# Altium schematic sheet Motor-Driver.SchDoc(Motor-Driver)
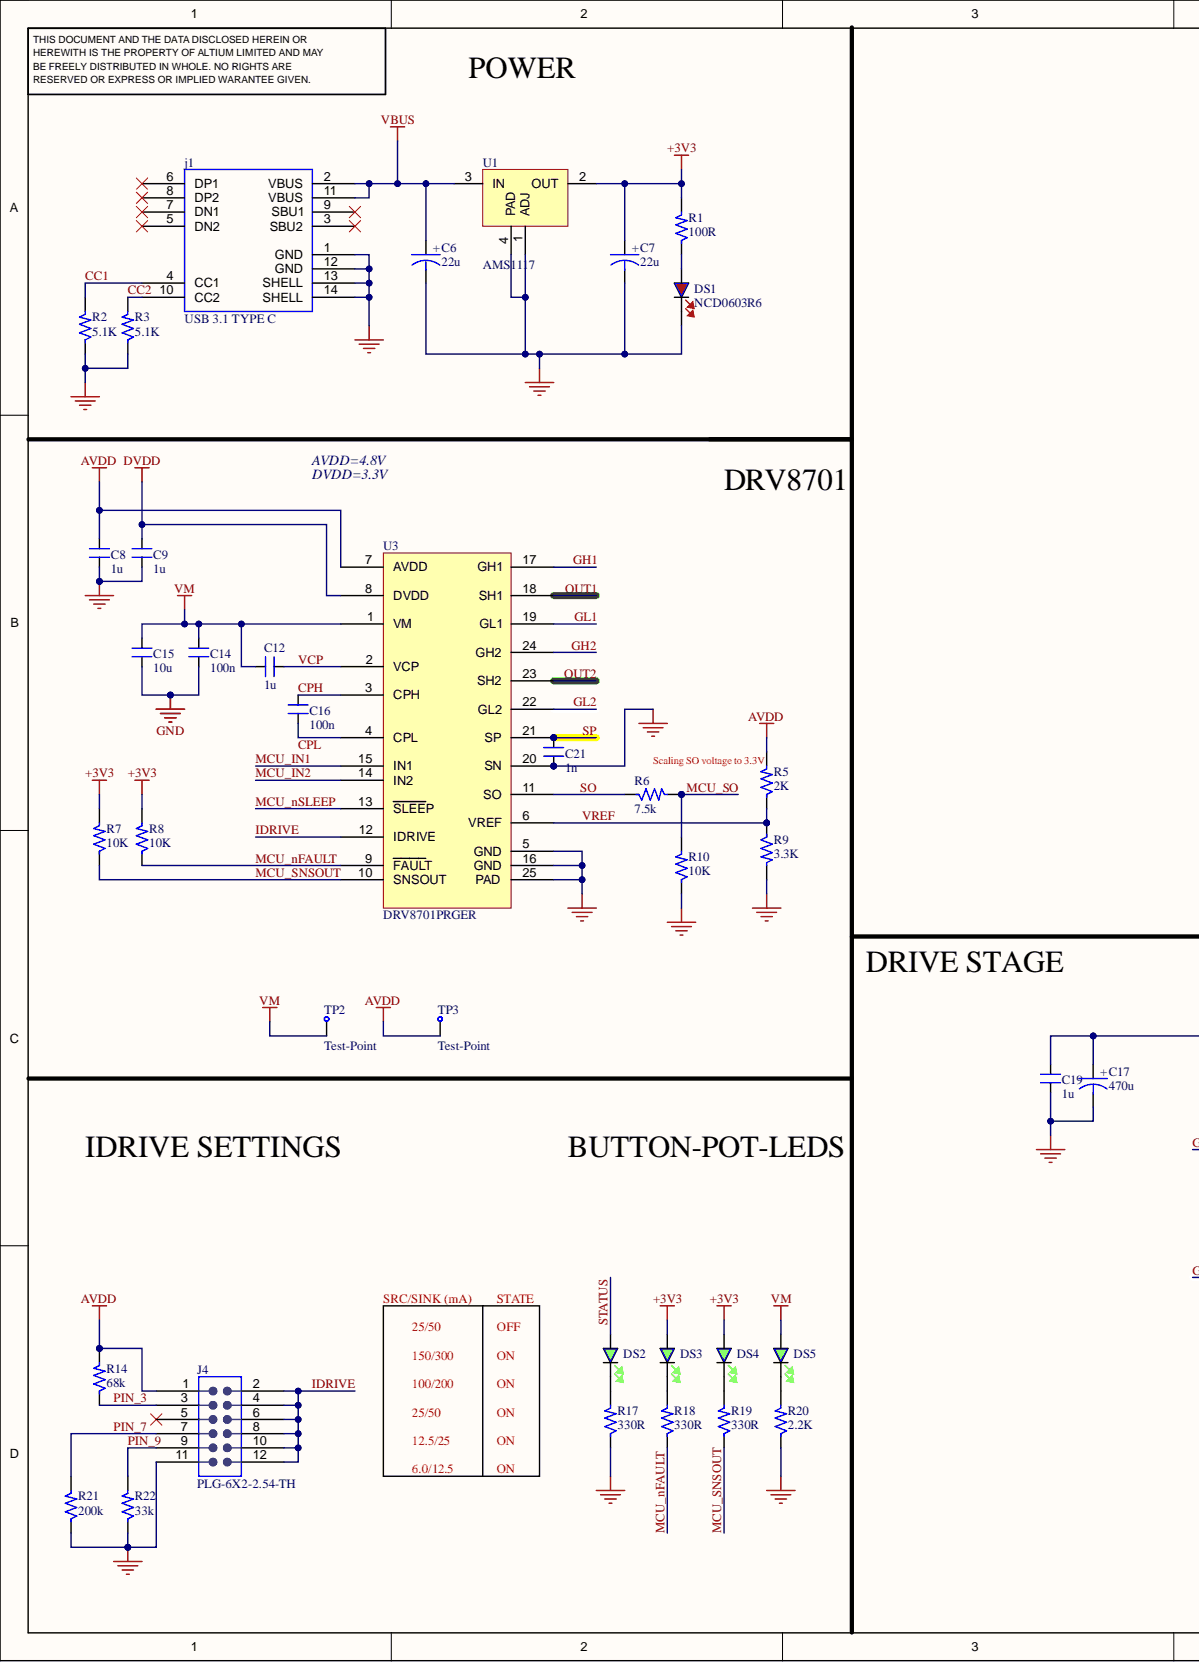
[diagram p0: Motor-Driver.SchDoc, left]
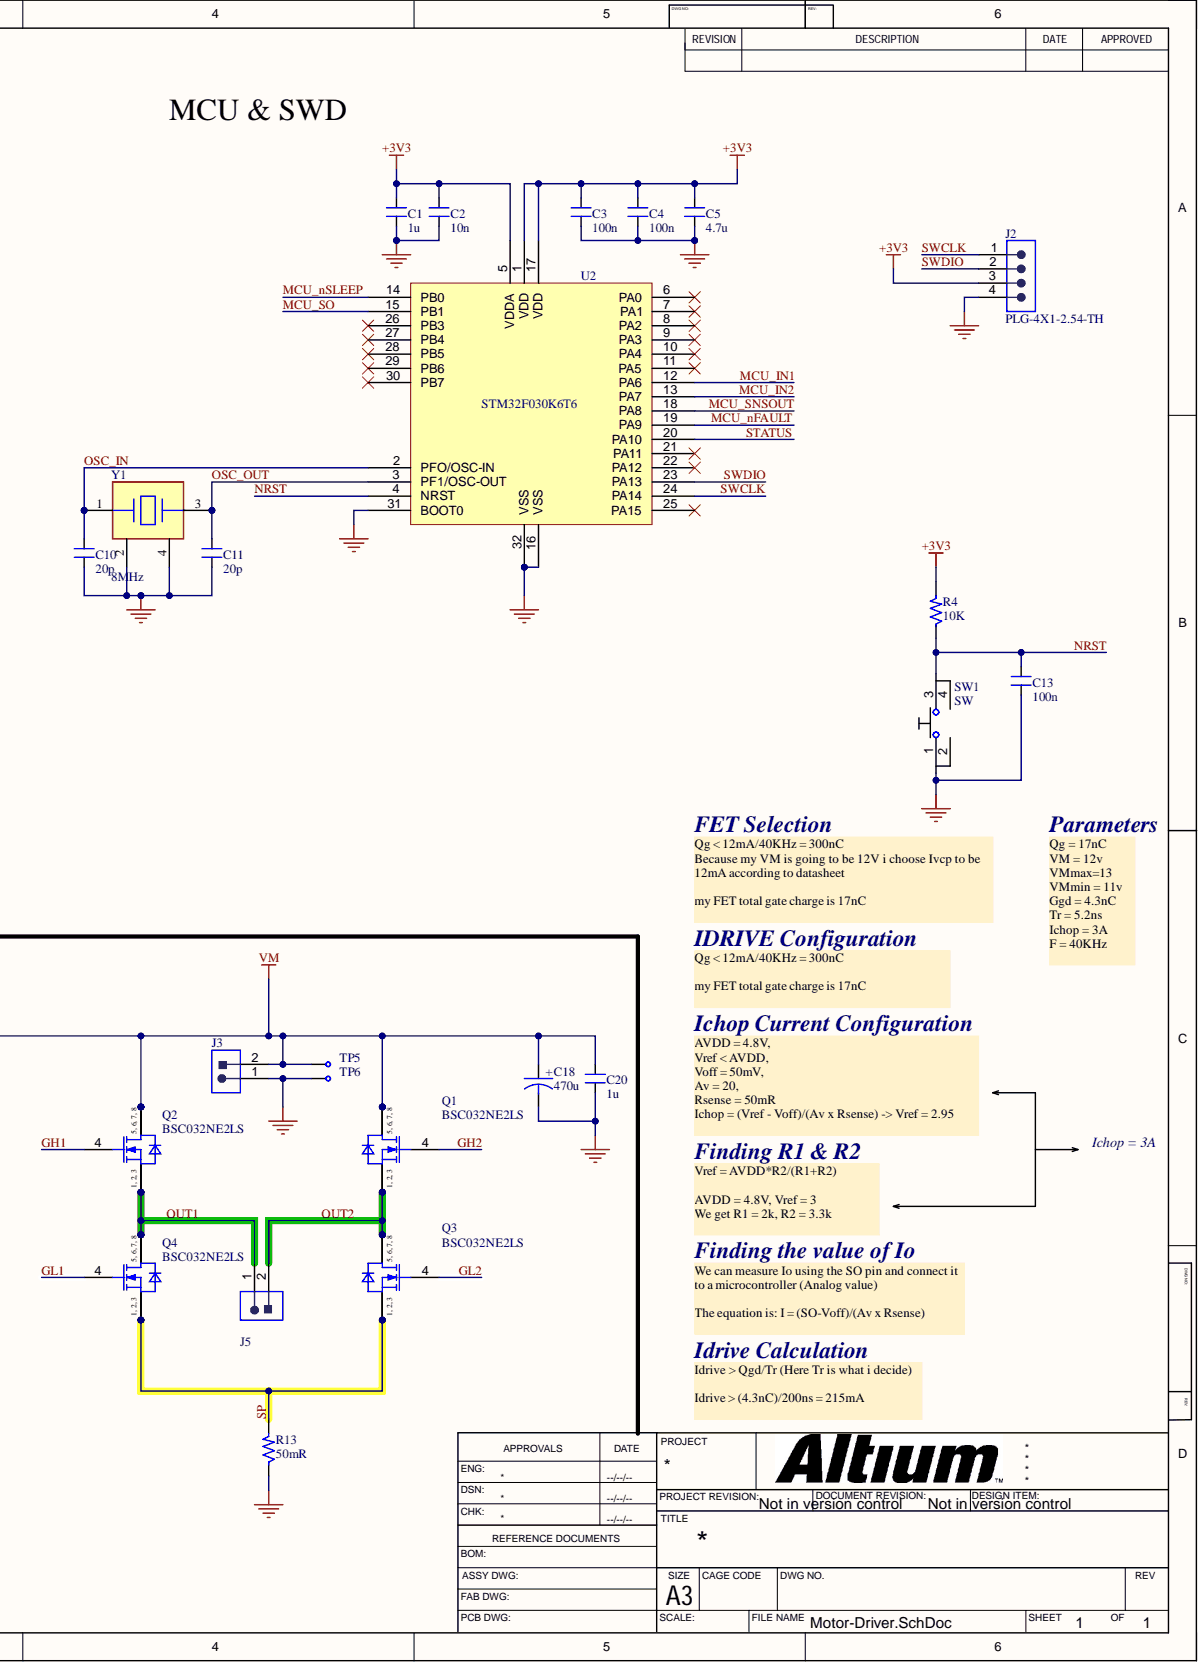
[diagram p1: Motor-Driver.SchDoc, right]

## Parts
C1  1u  [CAP_0603]
C2  10n  [CAP_0603]
C3  100n  [CAP_0603]
C4  100n  [CAP_0603]
C5  4.7u  [CAP_0603]
C6  22u  [CAP_1210]
C7  22u  [CAP_1210]
C8  1u  [CAP_0603]
C9  1u  [CAP_0603]
C10  20p  [CAP_0603]
C11  20p  [CAP_0603]
C12  1u  [CAP_0603]
C13  100n  [CAP_0603]
C14  100n  [CAP_0603]
C15  10u  [CAP_0603]
C16  100n  [CAP_0603]
C17  470u  [CAP-radial-8-12-5]
C18  470u  [CAP-radial-8-12-5]
C19  1u  [CAP_0603]
C20  1u  [CAP_0603]
C21  1n  [CAP_0402]
DS1  NCD0603R6  [LED_0603]
DS2  PSC-1608U50GC-G4  [LED_0603]  (value not drawn)
DS3  PSC-1608U50GC-G4  [LED_0603]  (value not drawn)
DS4  PSC-1608U50GC-G4  [LED_0603]  (value not drawn)
DS5  PSC-1608U50GC-G4  [LED_0603]  (value not drawn)
j1  USB 3.1 TYPE C  [USB3.1-TYPEC-SHOU HAN]
J2  PLG-4X1-2.54-TH  [PLG-4x1-2.54-TH]
J3  TB_2X1-5.08mm-F-THT  [TB_2X1-5.08mm-F-THT]  (value not drawn)
J4  PLG-6X2-2.54-TH  [PLG-6X2-2.54-TH]
J5  TB_2X1-5.08mm-F-THT  [TB_2X1-5.08mm-F-THT]  (value not drawn)
Q1  BSC032NE2LS  [IFX-PG-TDSON-8-6-V]
Q2  BSC032NE2LS  [IFX-PG-TDSON-8-6-V]
Q3  BSC032NE2LS  [IFX-PG-TDSON-8-6-V]
Q4  BSC032NE2LS  [IFX-PG-TDSON-8-6-V]
R1  100R  [RES_1206]
R2  5.1K  [RES_0603]
R3  5.1K  [RES_0603]
R4  10K  [RES_0603]
R5  2K  [RES_0603]
R6  7.5k  [RES_0603]
R7  10K  [RES_0603]
R8  10K  [RES_0603]
R9  3.3K  [RES_0603]
R10  10K  [RES_0603]
R13  50mR  [RES_1206]
R14  68k  [RES_0805]
R17  330R  [RES_0603]
R18  330R  [RES_0603]
R19  330R  [RES_0603]
R20  2.2K  [RES_0603]
R21  200k  [RES_0603]
R22  33k  [RES_0603]
SW1  SW  [SW]
TP2  Test-Point  [Test Point]
TP3  Test-Point  [Test Point]
TP5  Test-Point  [Test Point]
TP6  Test-Point  [Test Point]
U1  AMS1117  [SOT-23]
U2  STM32F030K6T6  [LQFP32-24-0.8-9.7-0.5x1.2-SMD]
U3  DRV8701PRGER  [VQFN-24-EP(4x4)]
Y1  8MHz  [XTAL-TX32258M4LBDE2T-HM]

## Nets
+3V3: C1.2 C2.2 C3.2 C4.2 C5.2 C7.1 DS3.A DS4.A J2.3 R1.2 R4.2 R7.2 R8.2 U1.2(OUT) U2.1(VDD) U2.5(VDDA) U2.17(VDD)
AVDD: C8.1 J4.1 R5.2 R14.2 TP3.1 U3.7(AVDD)
DVDD: C9.1 U3.8(DVDD)
GND: C1.1 C2.1 C3.1 C4.1 C5.1 C6.2 C7.2 C8.2 C9.2 C10.1 C11.1 C13.1 C14.1 C15.1 C17.2 C18.2 C19.2 C20.2 C21.1 DS1.K j1.1(GND) j1.12(GND) j1.13(SHELL) j1.14(SHELL) J2.4 J3.1 J4.11 R2.1 R3.1 R9.2 R10.1 R13.1 R17.1 R20.1 R21.1 R22.1 SW1.1 TP6.1 U1.1(ADJ) U1.4(PAD) U2.16(VSS) U2.31(BOOT0) U2.32(VSS) U3.5(GND) U3.16(GND) U3.20(SN) U3.25(PAD) Y1.2 Y1.4
VBUS: C6.1 j1.2(VBUS) j1.11(VBUS) U1.3(IN)
VM: C12.1 C14.2 C15.2 C17.1 C18.1 C19.1 C20.1 DS5.A J3.2 Q1.5 Q1.6 Q1.7 Q1.8 Q2.5 Q2.6 Q2.7 Q2.8 TP2.1 TP5.1 U3.1(VM)
CC1: j1.4(CC1) R2.2
CC2: j1.10(CC2) R3.2
CPH: C16.2 U3.3(CPH)
CPL: C16.1 U3.4(CPL)
GH1: Q2.4 U3.17(GH1)
GH2: Q1.4 U3.24(GH2)
GL1: Q4.4 U3.19(GL1)
GL2: Q3.4 U3.22(GL2)
IDRIVE: J4.2 J4.4 J4.6 J4.8 J4.10 J4.12 U3.12(IDRIVE)
MCU_IN1: U2.12(PA6) U3.15(IN1)
MCU_IN2: U2.13(PA7) U3.14(IN2)
MCU_nFAULT: R8.1 R18.1 U2.19(PA9) U3.9(FAULT)
MCU_nSLEEP: U2.14(PB0) U3.13(SLEEP)
MCU_SNSOUT: R7.1 R19.1 U2.18(PA8) U3.10(SNSOUT)
MCU_SO: R6.2 R10.2 U2.15(PB1)
NRST: C13.2 R4.1 SW1.3 U2.4(NRST)
OSC_IN: C10.2 U2.2(PFO/OSC-IN) Y1.1
OSC_OUT: C11.2 U2.3(PF1/OSC-OUT) Y1.3
OUT1: J5.1 Q2.1 Q2.2 Q2.3 Q4.5 Q4.6 Q4.7 Q4.8 U3.18(SH1)
OUT2: J5.2 Q1.1 Q1.2 Q1.3 Q3.5 Q3.6 Q3.7 Q3.8 U3.23(SH2)
PIN_3: J4.3 R14.1
PIN_7: J4.7 R21.2
PIN_9: J4.9 R22.2
SO: R6.1 U3.11(SO)
SP: C21.2 Q3.1 Q3.2 Q3.3 Q4.1 Q4.2 Q4.3 R13.2 U3.21(SP)
STATUS: DS2.A U2.20(PA10)
SWCLK: J2.1 U2.24(PA14)
SWDIO: J2.2 U2.23(PA13)
VCP: C12.2 U3.2(VCP)
VREF: R5.1 R9.1 U3.6(VREF)
NetDS1_A: DS1.A R1.1
NetDS2_K: DS2.K R17.2
NetDS3_K: DS3.K R18.2
NetDS4_K: DS4.K R19.2
NetDS5_K: DS5.K R20.2
Netj1_3: j1.3(SBU2) J4.5

Not connected: j1 5(DN2) 6(DP1) 7(DN1) 8(DP2) 9(SBU1); SW1 2 4; U2 6(PA0) 7(PA1) 8(PA2) 9(PA3) 10(PA4) 11(PA5) 21(PA11) 22(PA12) 25(PA15) 26(PB3) 27(PB4) 28(PB5) 29(PB6) 30(PB7)

## Library data of the parts
C1 C19 C20 = CAP_1uF-25V-±10%-0603: 25V 1uF X7R ±10% 0603; mfr: Samsung Electro-Mechanics; mpn: CL10B105KA8NNNC; distr: LCSC; dpn: C29936; pkg: 0603; Component Kind: Standard; Library Name: RLC.IntLib
C2 = CAP_10nF-50V-±5%-0603: 50V 10nF C0G ±5% 0603; mfr: Murata Electronics; mpn: GRM1885C1H103JA01D; distr: LCSC; dpn: C85973; pkg: 0603; Component Kind: Standard; Library Name: RLC.IntLib
C3 C4 C13 = CAP_100nF-25V-±10%-0603: 25V 100nF X7R ±10% 0603; mfr.: Samsung Electro-Mechanics; mpn: CL10B104KA8NNNC; distr.: LCSC; dpn: C1590; pkg: 0603; Component Kind: Standard; Library Name: RLC.IntLib
C5 = CAP_4.7uF-35V-±10%-0603: 35V 4.7uF X5R ±10% 0603 Multilayer Ceramic Capacitors MLCC - SMD/SMT ROHS; mfr: Murata Electronics; mpn: GRM188R6YA475KE15D; distr: LCSC; dpn: C162274; pkg: 0603; Component Kind: Standard; Library Name: RLC.IntLib
C6 C7 = CAP-P-22uF-16V-10%-1210(B): tantalum; distr: direnc; Component Kind: Standard; Library Name: RLC.SchLib; pkg: 1210(B)
C8 C9 C12 = CAP-1uF-25V-±10%-0603: 25V 1uF X7R ±10% 0603; mfr: Samsung Electro-Mechanics; mpn: CL10B105KA8NNNC; distr: LCSC; dpn: C29936; pkg: 0603; Component Kind: Standard; Library Name: RLC.IntLib
C10 C11 = CAP_20pF-50V-±5%-0603: 50V 20pF C0G ±5% 0603 Multilayer Ceramic Capacitors MLCC - SMD/SMT ROHS; mfr: Murata Electronics; mpn: GCM1885C1H200JA16D; distr: LCSC; dpn: C126554; pkg: 0603; Component Kind: Standard; Library Name: RLC.IntLib
C14 C16 = CAP-100nF-25V-±10%-0603: 25V 100nF X7R ±10% 0603; mfr.: Samsung Electro-Mechanics; mpn: CL10B104KA8NNNC; distr.: LCSC; dpn: C1590; pkg: 0603; Component Kind: Standard; Library Name: RLC.IntLib
C15 = CAP-10uF-25V-±20%-0603: 25V 10uF X5R ±20% 0603; mfr: Samsung Electro-Mechanics; mpn: CL10A106MA8NRNC; distr: LCSC; dpn: C96446; pkg: 0603; Component Kind: Standard; Library Name: RLC.IntLib
C17 C18 = CAP-P-470uF-plugin: aluminum; mfr: lescon; distr: direnc; pkg: tht; Component Kind: Standard; Library Name: RLC.IntLib
C21 = CAP-1nF-50V-±10%-0402: distr: direnc; dpn: 19298; pkg: 0402; Component Kind: Standard; Library Name: RLC.SchLib
DS1 = LED_Red-2V-25mA-0603: 25mA Colorless Transparent Lens 30mcd~80mcd 620nm~630nm -30℃~+85℃ Red 140° 65mW 1.6V~2.4V 0603 LED Indication - Discrete ROHS; mfr.: Foshan NationStar Optoelectronics; distr.: LCSC; dpn: C7429910; pkg: 0603; Component Kind: Standard; Library Name: Diode.IntLib; mpn: NCD0603R6
DS2-DS5 = LED_Green-3V-10mA-0603: Green 0603 LED Indication; mfr.: HONGLITRONIC; distr.: LCSC; dpn: C22371298; pkg: 0603; Component Kind: Standard; Library Name: Diode.IntLib; mpn: PSC-1608U50GC-G4
j1 = USB3.1_TYPEC_SMD: 5A USB 3.1 1 Horizontal attachment 16P Female -25℃~+85℃ Type-C SMD USB Connectors ROHS; mfr.: SHOU HAN; mpn: TYPE-C 16PIN 2MD(073); distr.: LCSC; dpn: C2765186; Component Kind: Standard; Library Name: Connectors.IntLib; pkg: SMD
Q1-Q4 = MOSFET-N-25V-84A-TDSON-8: Insulated-Gate Field-Effect Transistor (IGFET), N-Channel, Enhancement, Body Diode, Pin 1 Source, 2 Source, 3 Source, 4 Gate, 5 Drain, 6 Drain, 7 Drain, 8 Drain, 8 Pins; mfr: infineon; distr: direnc; dpn: 17622; Component Kind: Standard; mpn: BSC032NE2LS; pkg: TDSON-8; Library Name: Transistors-Thyristors.IntLib
R1 = RES-100R-250mW-±1-1206: mfr: yageo; mpn: RC1206FR-07100RL; distr: e-komponent; dpn: 311-100FRCT-ND; pkg: 1206; Component Kind: Standard; Library Name: RLC.IntLib
R2 R3 = RES-5.1K-333.333mW-±1%-0603: 333.333mW Thick Film Resistors 75V ±1% ±100ppm/℃ 5.1kΩ 0603 Chip Resistor - Surface Mount ROHS; Manufacturer: Vishay Intertech; Manufacturer Part No.: CRCW06035K10FKEAHP; Component Kind: Standard; Library Name: RLC.IntLib; Package: 0603; Supplier: LCSC; Supplier Part No.: C313783
R4 R7 R8 R10 = RES-10K-250mW-±1%-0603: 250mW Thick Film Resistors 150V ±100ppm/℃ ±1% 10kΩ 0603 Chip Resistor - Surface Mount ROHS; Distr. Part#: C510087; Mfr.: ROHM Semicon; Mfr. Part#: ESR03EZPF1002; Distr.: LCSC; Component Kind: Standard; Library Name: RLC.IntLib; Package: 0603
R5 = RES-2K-100mW-±1%-0603: 100mW Thick Film Resistors 75V ±100ppm/℃ ±1% 2kΩ 0603 Chip Resistor - Surface Mount ROHS; mfr.: Uniroyal Elec; mpn: 0603WAF2001T5E; distr.: LCSC; dpn: C22975; pkg: 0603; Component Kind: Standard; Library Name: RLC.IntLib
R6 = RES-7.5K-100mW-±5%-0603: mfr: yageo; mpn: RC0603JR-077K5L; distr: e-komponent; dpn: 311-7.5KGRCT-ND; pkg: 0603; Component Kind: Standard; Library Name: RLC.IntLib
R9 = RES-3,3K-250mW-±5%-0603: 250mW Thick Film Resistors 75V ±100ppm/℃ ±5% 3.3kΩ 0603 Chip Resistor - Surface Mount ROHS; mfr: Uniroyal Elec; mpn: ASO3W4J0332T5E; distr: LCSC; dpn: C966179; pkg: 0603; Component Kind: Standard; Library Name: RLC.IntLib
R13 = RES-50mR-1W-±1%-1206: 1W 50mΩ Solder Pad Current Sensing Resistors ±1% 1206 Current Sense Resistors / Shunt Resistors ROHS; mfr: TE connectivity; mpn: TLRP3A30DR050FTE; distr: e-komponent; dpn: 1712-TLRP3A30DR050FTECT-ND; pkg: 1206; Component Kind: Standard; Library Name: RLC.IntLib
R14 = RES-68K-0.125mW-±5%-0805: mfr: samsun-walson; distr: direnc; pkg: 0805; Component Kind: Standard; Library Name: RLC.IntLib
R17-R19 = RES-330R-100mW-±5%-0603: mfr: royalohm; distr: direnc; pkg: 0603; Component Kind: Standard; Library Name: RLC.IntLib
R20 = RES-2.2K-200mW-±1%-0603: 200mW Thick Film Resistors 75V ±100ppm/℃ ±1% 2.2kΩ 060; mfr: Uniroyal Elec; mpn: HP03W5F2201T5E; distr: LCSC; dpn: C2759425; pkg: 0603; Component Kind: Standard; Library Name: RLC.IntLib
R21 = RES-200K-100mW-±0.1%-0603: mfr: panasonic; mpn: ERA-3AEB204V; distr: e-komponent; dpn: P200KDBCT-ND; pkg: 0603; Component Kind: Standard; Library Name: RLC.IntLib
R22 = RES-33K-100mW-±5%-0603: mfr: royalohm; distr: direnc; pkg: 0603; Component Kind: Standard; Library Name: RLC.IntLib
SW1 = SW: Distr. Part#: CKN12313-1-ND; Mfr.: C&K; Mfr. Part#: PTS636 SL50 SMTR LFS; Distr.: e-komponent; Pkg.: SMD; Component Kind: Standard; Library Name: Switches.IntLib
U1 = LD0-AMS1117-3.3V: 3V3 Linear Voltage Regulator; mfr: advanced monolithic systems; mpn: AMD1117; distr: direnc; pkg: SOT223-3; Component Kind: Standard; Library Name: PMIC.IntLib
U3 = MD-DRV8701PRGER: VQFN-24-EP(4x4) Brushed DC Motor Drivers ROHS; mfr.: Texas Instrument; distr.: LCSC; dpn: C114178; Component Kind: Standard; Library Name: PMIC.IntLib; mpn: DRV8701PRGER; pkg: VQFN-24-EP(4x4)
Y1 = XTAL_8MHz-TX32258M4LBDE2T: 8MHz Surface Mount Crystal 20pF ±10ppm ±30ppm SMD3225-4P Crystals ROHS; mfr: Yajingxin; distr: lcsc; dpn: C5310727; Component Kind: Standard; Library Name: crystals-oscillators-resonators.IntLib; mpn: TX32258M4LBDE2T; pkg: SMD3225-4P
J2 = PLG-4X1-2.54-TH: Component Kind: Standard; Library Name: Connectors.IntLib
J3 J5 = TB_2X1-5.08mm-F-THT: Component Kind: Standard; Library Name: Connectors.IntLib
J4 = PLG-6X2-2.54-TH: Component Kind: Standard; Library Name: Connectors.IntLib
TP2 TP3 TP5 TP6 = Test-Point: Component Kind: Standard; Library Name: Connectors.SchLib
U2 = STM32F030K6T6: Component Kind: Standard; Library Name: Microcontrollers.IntLib
